# Altium schematic sheet Power Converter.SchDoc("Power Converter")
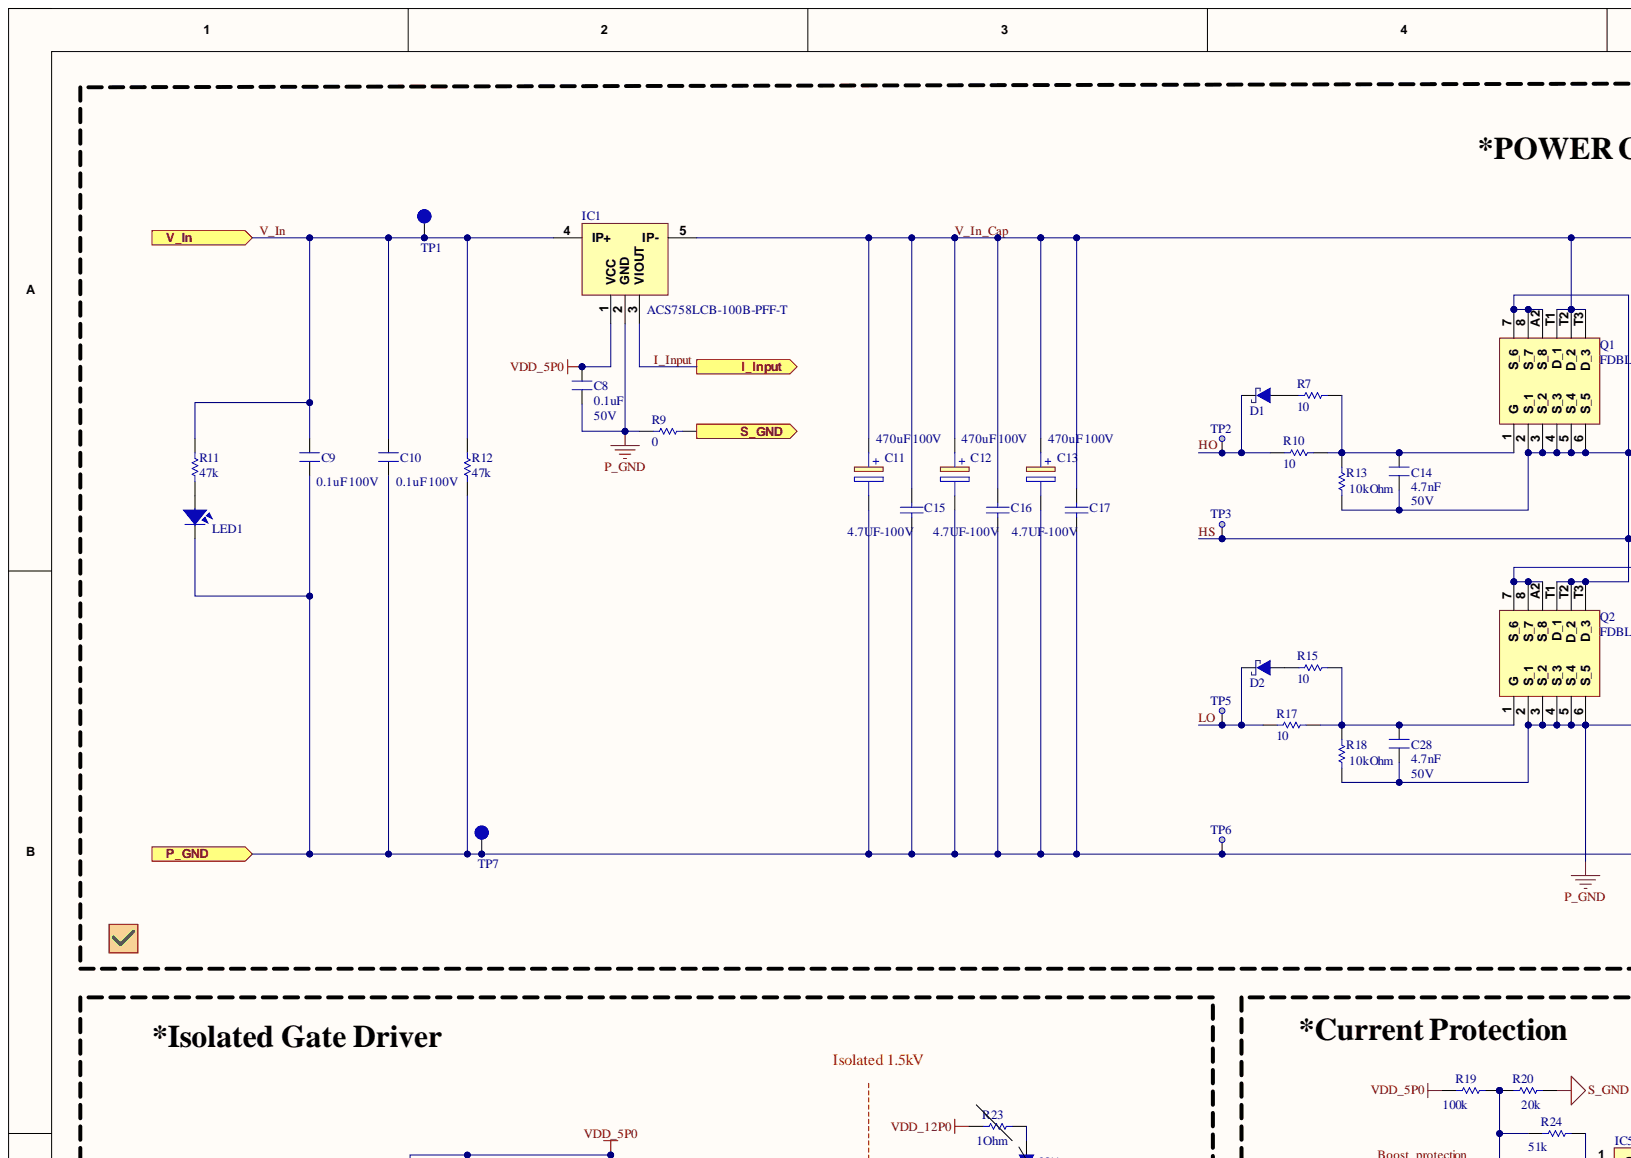
[diagram p0: Power Converter.SchDoc, top left]
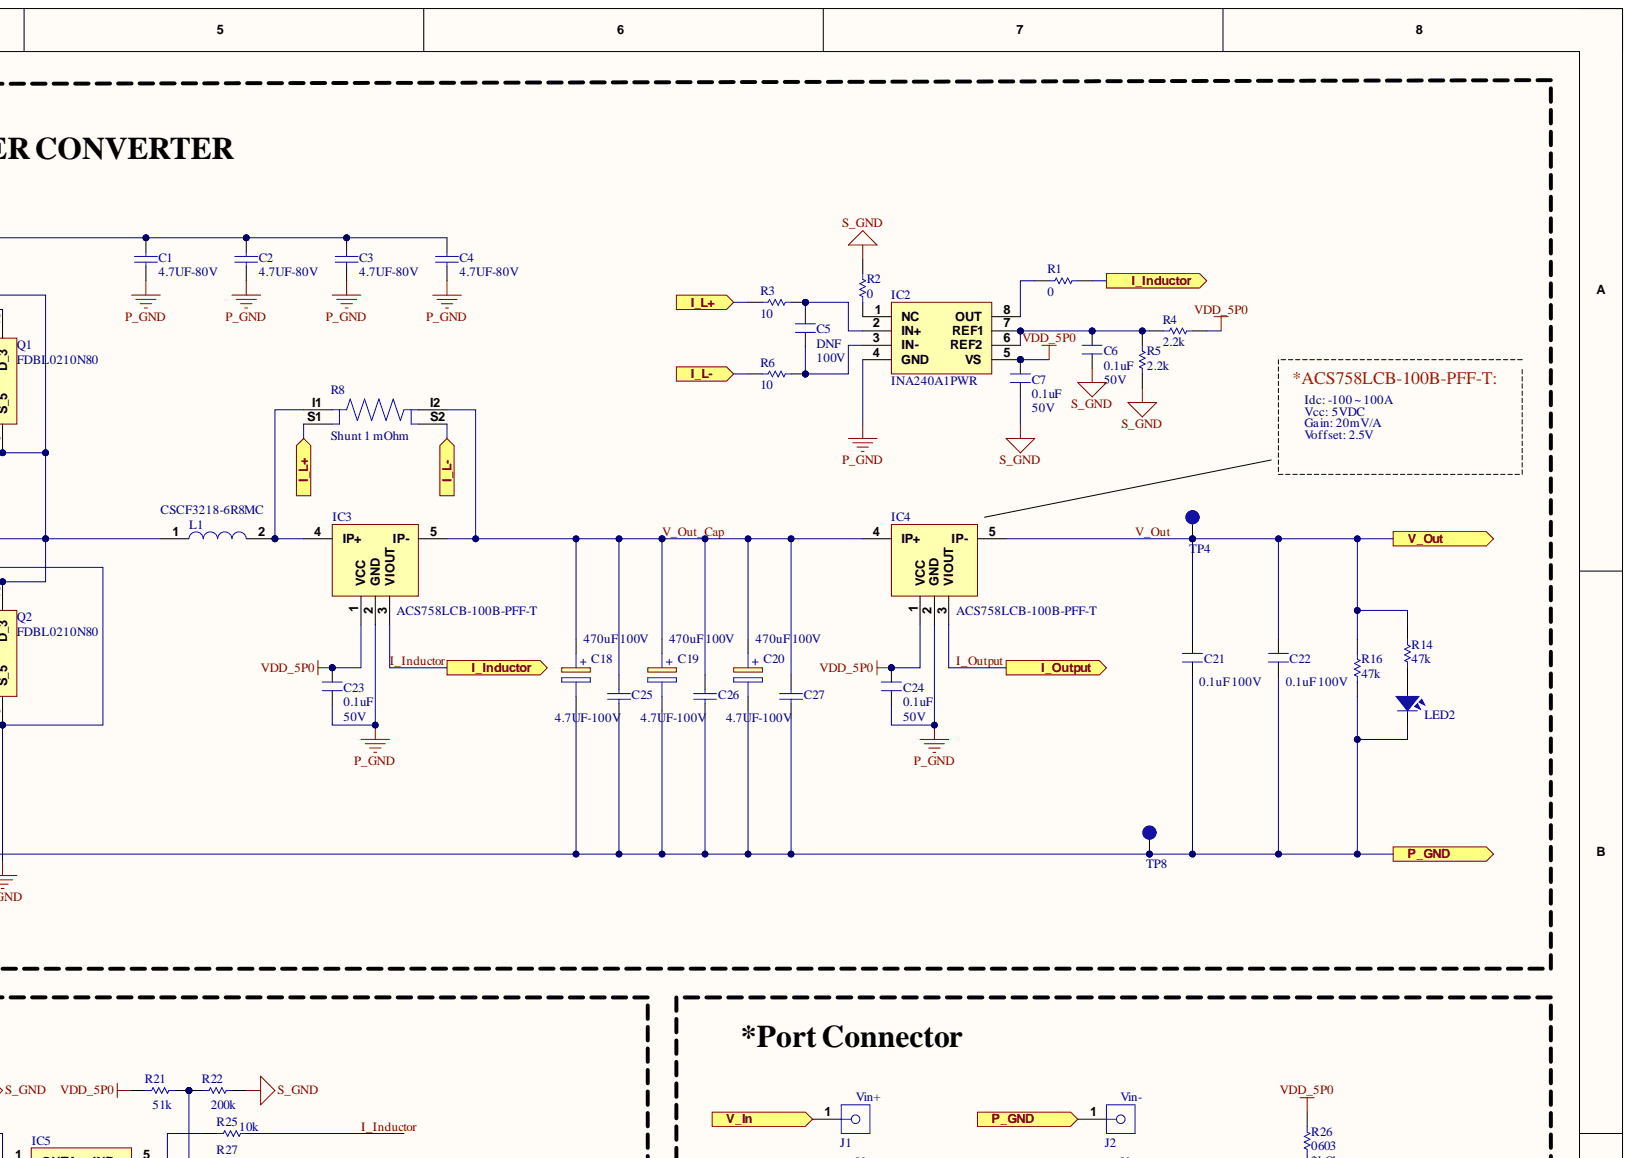
[diagram p1: Power Converter.SchDoc, top right]
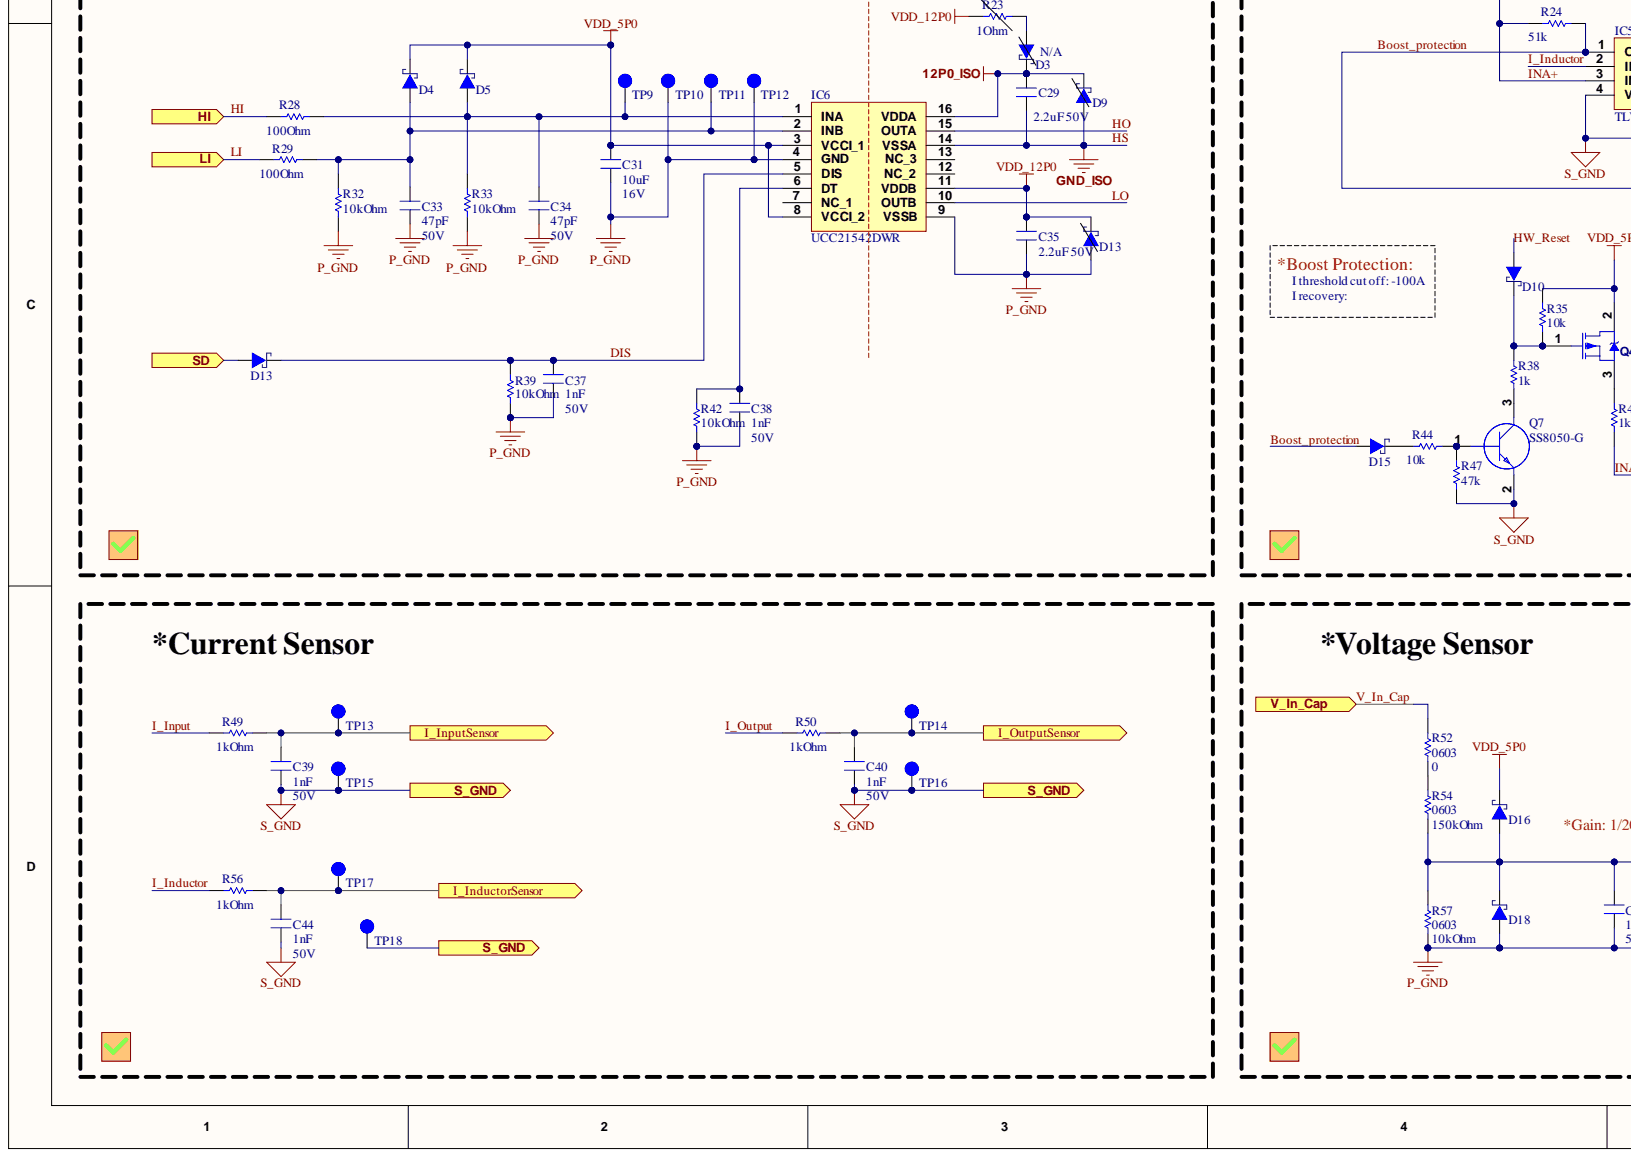
[diagram p2: Power Converter.SchDoc, bottom left]
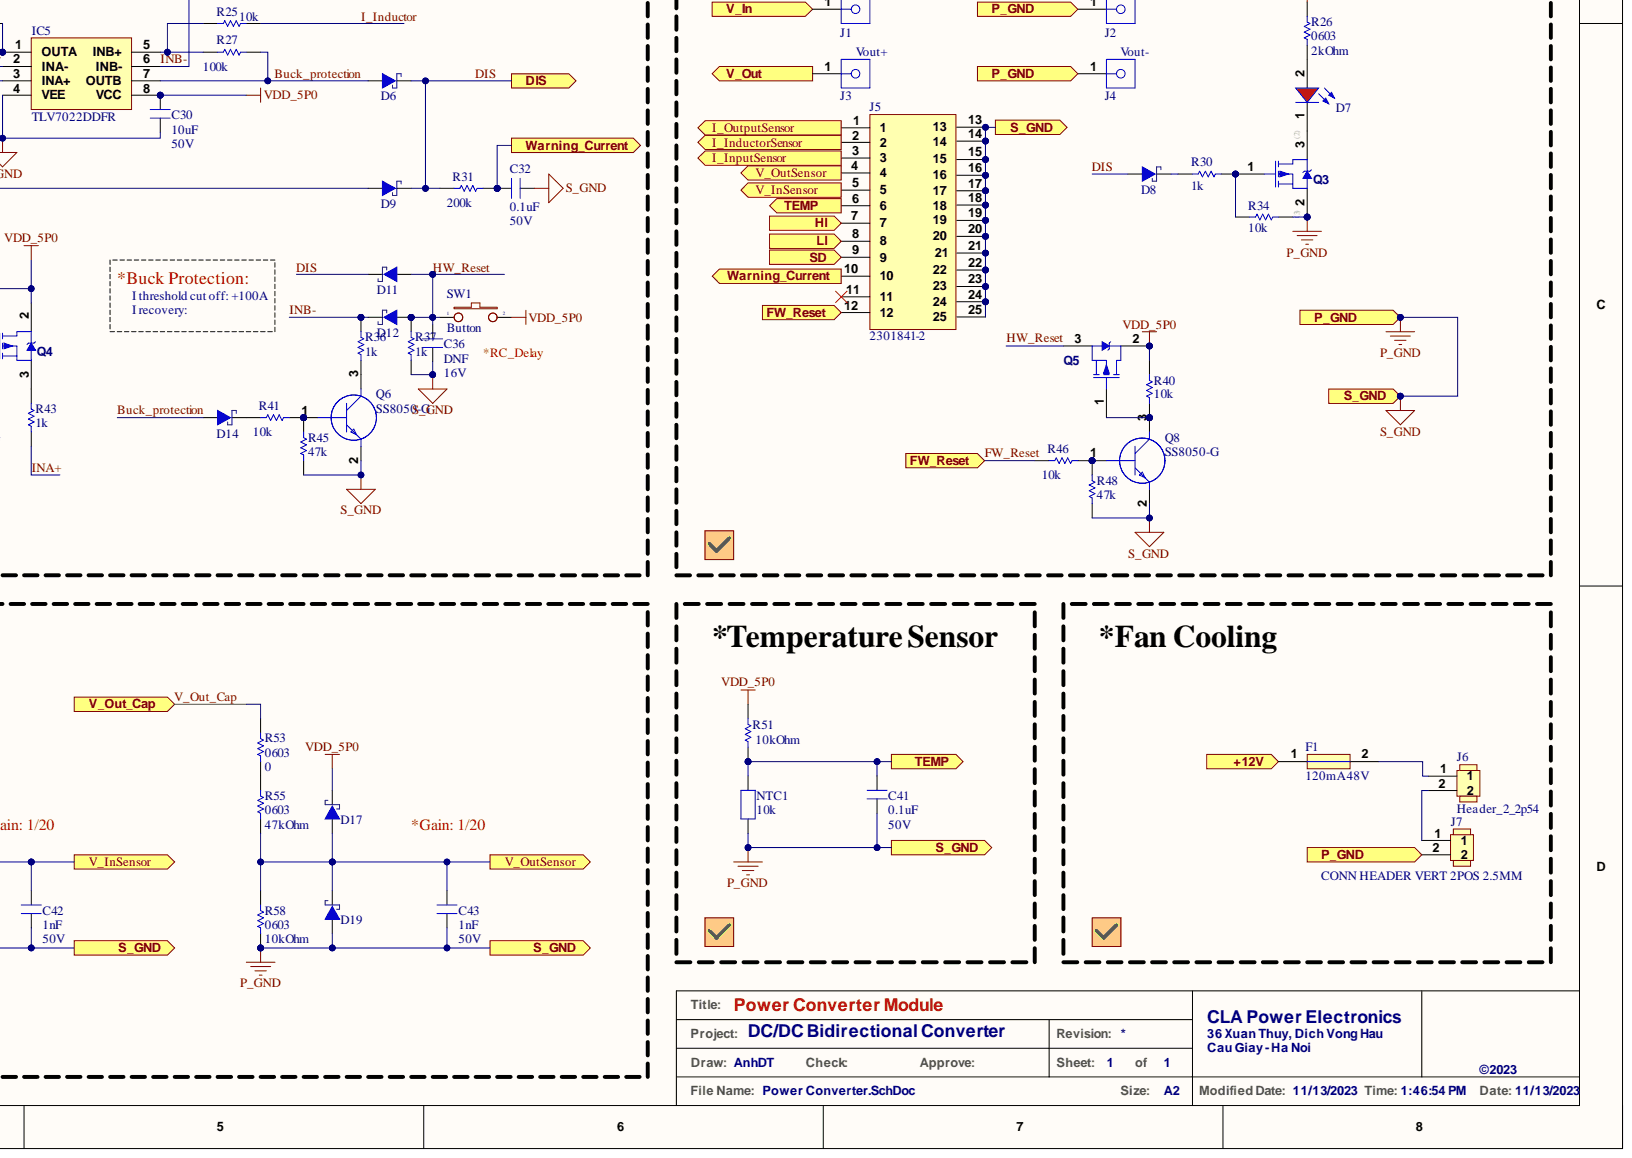
[diagram p3: Power Converter.SchDoc, bottom right]

## Parts
C1  value 4.7UF-80V  [C_1210]  (hidden comment: GRM32ER71K475KE14L)
C2  value 4.7UF-80V  [C_1210]  (hidden comment: GRM32ER71K475KE14L)
C3  value 4.7UF-80V  [C_1210]  (hidden comment: GRM32ER71K475KE14L)
C4  value 4.7UF-80V  [C_1210]  (hidden comment: GRM32ER71K475KE14L)
C5  DNF  value 100V  [C_0603]
C6  0.1uF  value 50V  [C_0603]
C7  0.1uF  value 50V  [C_0603]
C8  0.1uF  value 50V  [C_0603]
C9  0.1uF 100V  [C_1206]
C10  0.1uF 100V  [C_1206]
C11  470uF 100V  [CAP-ALU-13MM*30MM]
C12  470uF 100V  [CAP-ALU-13MM*30MM]
C13  470uF 100V  [CAP-ALU-13MM*30MM]
C14  4.7nF  value 50V  [C_0603]
C15  value 4.7UF-100V  [C_1210]  (hidden comment: GRM32ER71K475KE14L)
C16  value 4.7UF-100V  [C_1210]  (hidden comment: GRM32ER71K475KE14L)
C17  value 4.7UF-100V  [C_1210]  (hidden comment: GRM32ER71K475KE14L)
C18  470uF 100V  [CAP-ALU-13MM*30MM]
C19  470uF 100V  [CAP-ALU-13MM*30MM]
C20  470uF 100V  [CAP-ALU-13MM*30MM]
C21  0.1uF 100V  [C_1206]
C22  0.1uF 100V  [C_1206]
C23  0.1uF  value 50V  [C_0603]
C24  0.1uF  value 50V  [C_0603]
C25  value 4.7UF-100V  [C_1210]  (hidden comment: GRM32ER71K475KE14L)
C26  value 4.7UF-100V  [C_1210]  (hidden comment: GRM32ER71K475KE14L)
C27  value 4.7UF-100V  [C_1210]  (hidden comment: GRM32ER71K475KE14L)
C28  4.7nF  value 50V  [C_0603]
C29  2.2uF 50V  [C_1206]
C30  10uF  value 50V  [C_0603]
C31  10uF  value 16V  [C_0805]
C32  0.1uF  value 50V  [C_0603]
C33  47pF  value 50V  [C_0603]
C34  47pF  value 50V  [C_0603]
C35  2.2uF 50V  [C_1206]
C36  DNF  value 16V  [C_0805]
C37  1nF  value 50V  [C_0603]
C38  1nF  value 50V  [C_0603]
C39  1nF  value 50V  [C_0603]
C40  1nF  value 50V  [C_0603]
C41  0.1uF  value 50V  [C_0603]
C42  1nF  value 50V  [C_0603]
C43  1nF  value 50V  [C_0603]
C44  1nF  value 50V  [C_0603]
D1  B0520WS-7-F  [SOD323F]  (value not drawn)
D2  B0520WS-7-F  [SOD323F]  (value not drawn)
D3  PMEG2010AEJ,115  [SOD123]  (value not drawn)
D4  PMEG2010AEJ,115  [SOD323F]  (value not drawn)
D5  PMEG2010AEJ,115  [SOD323F]  (value not drawn)
D6  PMEG2010AEJ,115  [SOD323F]  (value not drawn)
D7  LED-SMD  [SM-LED-0805-Red]  (value not drawn)
D8  PMEG2010AEJ,115  [SOD323F]  (value not drawn)
D9  PMEG2010AEJ,115  [SOD123]  (value not drawn)
D9  PMEG2010AEJ,115  [SOD323F]  (value not drawn)
D10  PMEG2010AEJ,115  [SOD323F]  (value not drawn)
D11  PMEG2010AEJ,115  [SOD323F]  (value not drawn)
D12  PMEG2010AEJ,115  [SOD323F]  (value not drawn)
D13  PMEG2010AEJ,115  [SOD123]  (value not drawn)
D13  PMEG2010AEJ,115  [SOD323F]  (value not drawn)
D14  PMEG2010AEJ,115  [SOD323F]  (value not drawn)
D15  PMEG2010AEJ,115  [SOD323F]  (value not drawn)
D16  PMEG2010AEJ,115  [SOD323F]  (value not drawn)
D17  PMEG2010AEJ,115  [SOD323F]  (value not drawn)
D18  PMEG2010AEJ,115  [SOD323F]  (value not drawn)
D19  PMEG2010AEJ,115  [SOD323F]  (value not drawn)
F1  120mA 48V  [Fuse-SMD-1206]
IC1  ACS758LCB-100B-PFF-T  [ACS772ECB200BPFFT]
IC2  INA240A1PWR  [SOP65P640X120-8N]
IC3  ACS758LCB-100B-PFF-T  [ACS772ECB200BPFFT]
IC4  ACS758LCB-100B-PFF-T  [ACS772ECB200BPFFT]
IC5  TLV7022DDFR  [SOT65P280X110-8N]
IC6  UCC21542DWR  [SOIC127P1030X265-16N]
J1  Vin+  [HOLE_5mm_RED]
J2  Vin-  [HOLE_5mm_BLACK]
J3  Vout+  [HOLE_5mm_RED]
J4  Vout-  [HOLE_5mm_BLACK]
J5  2301841-2  [TE_2301841-2]
J6  Header_2_2p54  [JUMP_1x2]
J7  CONN HEADER VERT 2POS 2.5MM  [HEADER_2]
L1  CSCF3218-6R8MC  [CSCF3218-6R8MC]
LED1  LED SMD  [SM-LED-0805-Blue]  (value not drawn)
LED2  LED SMD  [SM-LED-0805-Blue]  (value not drawn)
NTC1  10k  [NTC 0805]
Q1  FDBL0210N80  [NTBLS1D5N10MC - duplicate]
Q2  FDBL0210N80  [NTBLS1D5N10MC - duplicate]
Q3  IRLML6402TRPBF  [SOT23-3]  (value not drawn)
Q4  IRLML6402TRPBF  [SOT23-3]  (value not drawn)
Q5  IRLML6402TRPBF  [SOT23-3]  (value not drawn)
Q6  SS8050-G  [sot23-3]
Q7  SS8050-G  [sot23-3]
Q8  SS8050-G  [sot23-3]
R1  0  [R_0603]
R2  0  [R_0603]
R3  10  [R_0603]
R4  2.2k  [R_0603]
R5  2.2k  [R_0603]
R6  10  [R_0603]
R7  10  [R_0805]
R8  Shunt 1 mOhm  value 1 mOhm  [PSG4/PSF4]
R9  value 0  [R_0603]  (hidden comment: 0603)
R10  10  [R_0805]
R11  47k  [R_0603]
R12  47k  [R_1206]
R13  value 10kOhm  [R_0603]  (hidden comment: 0603)
R14  47k  [R_0603]
R15  10  [R_0805]
R16  47k  [R_1206]
R17  10  [R_0805]
R18  value 10kOhm  [R_0603]  (hidden comment: 0603)
R19  value 100k  [R_0603]  (hidden comment: 0603)
R20  value 20k  [R_0603]  (hidden comment: 0603)
R21  51k  [R_0603]
R22  200k  [R_0603]
R23  value 1Ohm  [R_0603]  (hidden comment: 0603)
R24  value 51k  [R_0603]  (hidden comment: 0603)
R25  10k  [R_0603]
R26  0603  value 2kOhm  [R_0603]
R27  value 100k  [R_0603]  (hidden comment: 0603)
R28  value 100Ohm  [R_0603]  (hidden comment: 0603)
R29  value 100Ohm  [R_0603]  (hidden comment: 0603)
R30  1k  [R_0603]
R31  200k  [R_0603]
R32  value 10kOhm  [R_0603]  (hidden comment: 0603)
R33  value 10kOhm  [R_0603]  (hidden comment: 0603)
R34  10k  [R_0603]
R35  10k  [R_0603]
R36  1k  [R_0603]
R37  1k  [R_0603]
R38  1k  [R_0603]
R39  value 10kOhm  [R_0603]  (hidden comment: 0603)
R40  10k  [R_0603]
R41  10k  [R_0603]
R42  value 10kOhm  [R_0603]  (hidden comment: 0603)
R43  1k  [R_0603]
R44  10k  [R_0603]
R45  47k  [R_0603]
R46  10k  [R_0603]
R47  47k  [R_0603]
R48  47k  [R_0603]
R49  value 1kOhm  [R_0603]  (hidden comment: 0603)
R50  value 1kOhm  [R_0603]  (hidden comment: 0603)
R51  value 10kOhm  [R_0603]  (hidden comment: 0603)
R52  0603  value 0  [R_0603]
R53  0603  value 0  [R_0603]
R54  0603  value 150kOhm  [R_0603]
R55  0603  value 47kOhm  [R_0603]
R56  value 1kOhm  [R_0603]  (hidden comment: 0603)
R57  0603  value 10kOhm  [R_0603]
R58  0603  value 10kOhm  [R_0603]
SW1  Button  [BUTTON_2P_SMD]
TP1  Test Point  [TP_1mm_through_hole]  (value not drawn)
TP2  TP  [TP_1mm]  (no BOM)
TP3  TP  [TP_1mm]  (no BOM)
TP4  Test Point  [TP_1mm_through_hole]  (value not drawn)
TP5  TP  [TP_1mm]  (no BOM)
TP6  TP  [TP_1mm]  (no BOM)
TP7  Test Point  [TP_1mm_through_hole]  (value not drawn)
TP8  Test Point  [TP_1mm_through_hole]  (value not drawn)
TP9  Test Point  [TP_1mm_through_hole]  (value not drawn)
TP10  Test Point  [TP_1mm_through_hole]  (value not drawn)
TP11  Test Point  [TP_1mm_through_hole]  (value not drawn)
TP12  Test Point  [TP_1mm_through_hole]  (value not drawn)
TP13  Test Point  [TP_1mm_through_hole]  (value not drawn)
TP14  Test Point  [TP_1mm_through_hole]  (value not drawn)
TP15  Test Point  [TP_1mm_through_hole]  (value not drawn)
TP16  Test Point  [TP_1mm_through_hole]  (value not drawn)
TP17  Test Point  [TP_1mm_through_hole]  (value not drawn)
TP18  Test Point  [TP_1mm_through_hole]  (value not drawn)

## Ports
+12V, DIS, FW_Reset, HI, I_Inductor, I_InductorSensor, I_Input, I_InputSensor, I_L+, I_L-, I_Output, I_OutputSensor, LI, P_GND, S_GND, SD, TEMP, V_In, V_In_Cap, V_InSensor, V_Out, V_Out_Cap, V_OutSensor, Warning_Current

## Nets
12P0_ISO: C29.2 D3.2 D9.2 IC6.16(VDDA)
VDD_5P0: C7.1 C8.2 C23.2 C24.2 C30.2 C31.2 D4.2 D5.2 D16.2 D17.2 IC1.1(VCC) IC2.5(VS) IC3.1(VCC) IC4.1(VCC) IC5.8(VCC) IC6.3(VCCI_1) IC6.8(VCCI_2) Q4.2 Q5.2 R4.1 R19.1 R21.1 R26.2 R35.2 R40.2 R51.2 SW1.2
VDD_12P0: C35.1 D13.2 IC6.11(VDDB) R23.1
+12V: F1.1 ; port +12V
Boost_protection: D9.1 D15.1 IC5.1(OUTA) R24.2
Buck_protection: D6.1 D14.1 IC5.7(OUTB) R27.2
DIS: C37.2 D6.2 D8.1 D9.2 D11.2 D13.2 IC6.5(DIS) R31.1 R39.2 ; port DIS
FW_Reset: J5.12 R46.1 ; port FW_Reset
HI: J5.7 R28.1 ; port HI
HO: D1.2 IC6.15(OUTA) R10.1 TP2.1
HS: C14.1 C29.1 D9.1 IC6.14(VSSA) L1.1 Q1.2(S_1) Q1.3(S_2) Q1.4(S_3) Q1.5(S_4) Q1.6(S_5) Q1.7(S_6) Q1.8(S_7) Q1.A2(S_8) Q2.T1(D_1) Q2.T2(D_2) Q2.T3(D_3) R13.1 TP3.1
HW_Reset: C36.2 D10.1 D11.1 D12.1 Q5.3 R37.2 SW1.1
I_Inductor: IC3.3(VIOUT) IC5.2(INA-) R1.1 R25.2 R56.1 ; port I_Inductor
I_InductorSensor: C44.2 J5.2 R56.2 TP17.1 ; port I_InductorSensor
I_Input: IC1.3(VIOUT) R49.1 ; port I_Input
I_InputSensor: C39.2 J5.3 R49.2 TP13.1 ; port I_InputSensor
I_Output: IC4.3(VIOUT) R50.1 ; port I_Output
I_OutputSensor: C40.2 J5.1 R50.2 TP14.1 ; port I_OutputSensor
INA+: IC5.3(INA+) R19.2 R20.1 R24.1 R43.1
INB-: D12.2 IC5.6(INB-) R21.2 R22.1 R36.2
LI: J5.8 R29.1 ; port LI
LO: D2.2 IC6.10(OUTB) R17.1 TP5.1
P_GND: C1.1 C2.1 C3.1 C4.1 C6.1 C7.2 C8.1 C9.1 C10.1 C11.2 C12.2 C13.2 C15.1 C16.1 C17.1 C18.2 C19.2 C20.2 C21.1 C22.1 C23.1 C24.1 C25.1 C26.1 C27.1 C28.1 C30.1 C31.1 C32.2 C33.2 C34.2 C35.2 C36.1 C37.1 C38.1 C39.1 C40.1 C41.1 C42.1 C43.1 C44.1 D13.1 D18.1 D19.1 IC1.2(GND) IC2.4(GND) IC3.2(GND) IC4.2(GND) IC5.4(VEE) IC6.4(GND) IC6.9(VSSB) J2.1 J4.1 J5.13 J5.14 J5.15 J5.16 J5.17 J5.18 J5.19 J5.20 J5.21 J5.22 J5.23 J5.24 J5.25 J7.2 LED1.2 LED2.2 NTC1.1 Q2.2(S_1) Q2.3(S_2) Q2.4(S_3) Q2.5(S_4) Q2.6(S_5) Q2.7(S_6) Q2.8(S_7) Q2.A2(S_8) Q3.3 Q6.2 Q7.2 Q8.2 R2.2 R5.1 R9.1 R9.2 R12.1 R16.1 R18.1 R20.2 R22.2 R32.1 R33.1 R34.2 R37.1 R39.1 R42.1 R45.1 R47.1 R48.1 R57.1 R58.1 TP6.1 TP7.1 TP8.1 TP10.1 TP12.1 TP15.1 TP16.1 TP18.1 ; port P_GND, port S_GND
SD: D13.1 J5.9 ; port SD
TEMP: C41.2 J5.6 NTC1.2 R51.1 ; port TEMP
V_In: C9.2 C10.2 IC1.4(IP+) J1.1 R11.1 R12.2 TP1.1 ; port V_In
V_In_Cap: C1.2 C2.2 C3.2 C4.2 C11.1 C12.1 C13.1 C15.2 C16.2 C17.2 IC1.5(IP-) Q1.T1(D_1) Q1.T2(D_2) Q1.T3(D_3) R52.2 ; port V_In_Cap
V_InSensor: C42.2 D16.1 D18.2 J5.5 R54.1 R57.2 ; port V_InSensor
V_Out: C21.2 C22.2 IC4.5(IP-) J3.1 R14.1 R16.2 TP4.1 ; port V_Out
V_Out_Cap: C18.1 C19.1 C20.1 C25.2 C26.2 C27.2 IC3.5(IP-) IC4.4(IP+) R8.I2 R53.2 ; port V_Out_Cap
V_OutSensor: C43.2 D17.1 D19.2 J5.4 R55.1 R58.2 ; port V_OutSensor
Warning_Current: C32.1 J5.10 R31.2 ; port Warning_Current
NetC5_1: C5.1 IC2.2(IN+) R3.1
NetC5_2: C5.2 IC2.3(IN-) R6.1
NetC6_2: C6.2 IC2.6(REF2) IC2.7(REF1) R4.2 R5.2
NetC14_2: C14.2 Q1.1(G) R7.2 R10.2 R13.2
NetC28_2: C28.2 Q2.1(G) R15.2 R17.2 R18.2
NetC33_1: C33.1 D4.1 IC6.2(INB) R29.2 R32.2 TP11.1
NetC34_1: C34.1 D5.1 IC6.1(INA) R28.2 R33.2 TP9.1
NetC38_2: C38.2 IC6.6(DT) R42.2
NetD1_1: D1.1 R7.1
NetD2_1: D2.1 R15.1
NetD3_1: D3.1 R23.2
NetD7_1: D7.1 Q3.2
NetD7_2: D7.2 R26.1
NetD8_2: D8.2 R30.2
NetD10_2: D10.2 Q4.1 R35.1 R38.2
NetD14_2: D14.2 R41.1
NetD15_2: D15.2 R44.1
NetF1_2: F1.2 J6.1
NetIC2_1: IC2.1(NC) R2.1
NetIC2_8: IC2.8(OUT) R1.2
NetIC3_4: IC3.4(IP+) L1.2 R8.I1
NetIC5_5: IC5.5(INB+) R25.1 R27.1
NetJ6_2: J6.2 J7.1
NetLED1_1: LED1.1 R11.2
NetLED2_1: LED2.1 R14.2
NetQ3_1: Q3.1 R30.1 R34.1
NetQ4_3: Q4.3 R43.2
NetQ5_1: Q5.1 Q8.3 R40.1
NetQ6_1: Q6.1 R41.2 R45.2
NetQ6_3: Q6.3 R36.1
NetQ7_1: Q7.1 R44.2 R47.2
NetQ7_3: Q7.3 R38.1
NetQ8_1: Q8.1 R46.2 R48.2
NetR3_2: R3.2 R8.S1
NetR6_2: R6.2 R8.S2
NetR52_1: R52.1 R54.2
NetR53_1: R53.1 R55.2

Not connected: IC6 7(NC_1) 12(NC_2) 13(NC_3); J5 11

## Library data of the parts
C1-C4 C15-C17 C25-C27 = Cap 1210: CAP CER 4.7uF 80V X7R 1210; Manufacturer: TDK Corporation; Description std: CAP CER 4.7UF 100V X7S 1210; Category: Capacitors; Component Kind: Standard; Digikey Price/1KU: 0.56250; Digikey Price/1unit: 1.64; Library Name: DC_DC_Power_V3.0.IntLib; Link: https://www.digikey.com/product-detail/en/tdk-corporation/C3225X7S2A475M200AB/445-6043-2-ND/2443521; Package: 1210; Part Number: GRM32ER71K475KE14L
C5 = Cap_Cer_0603_1nF: Manufacturer: Murata Electronics; Part Code: S1200-000081; Part Number: GCM188R71E102KA37D; Description SEL: CAP CER 1000PF 25V X7R 0603; Point: 2; Component Kind: Standard; Description: DNF; Footprint Type: SMD; Library Name: S1200_Capacitor.SchLib
C6 C7 = Cap_Cer_0603_1nF: CAP CER 0.1UF 50V X7R 0603; Manufacturer: Murata Electronics; Part Code: S1200-000081; Part Number: GCM188R71E102KA37D; Description SEL: CAP CER 1000PF 25V X7R 0603; Point: 2; Component Kind: Standard; Footprint Type: SMD; Library Name: S1200_Capacitor.SchLib
C8 C23 C24 C32 = Cap_Cer_0603_1nF_25V: CAP CER 0.1UF 16V X5R 0603; Manufacturer: Murata Electronics; Part Code: S1200-000082; Part Number: CL21A106KOCLRNC; Description SEL: CAP CER 10UF 16V X5R 0805; Point: 2; Component Kind: Standard; Footprint Type: SMD; Library Name: S1200_Capacitor.SchLib
C9 C10 C21 C22 C29 C35 = Cap_Cer_1206_1uF: Ceramic Capacitor 1206 (+-10% TH)/(+10%SMD); Manufacturer: TDK Corporation; Part Code: S1200-000129; Part Number: C3216X7R2A105K160AA; Description SEL: CAP CER 1UF 100V X7R 1206; Point: 2; Component Kind: Standard; Footprint Type: SMD; Library Name: S1200_Capacitor.SchLib
C11-C13 C18-C20 = 100ZLH470MEFC16X31.5: CAP ALUM 470UF 20% 80V RADIAL; Manufacturer_Name: Panasonic Electronic Components; Part Number: EEU-FS1K471L; Value: 470uF 80V; Arrow Part Number: EEU-FS1K471L; Arrow Price/Stock: https://www.arrow.com/en/products/100zlh470mefc16x31.5/rubycon?region=nac; Component Kind: Standard; Height: 33.5mm; Mouser Part Number: EEU-FS1K471L; Mouser Price/Stock: https://www.digikey.com/en/products/detail/panasonic-electronic-components/EEU-FS1K471L/9597020; Library Name: Tienanh.SchLib
C14 C28 = Cap_Cer_0603_1nF_25V: CAP CER 0805 4.7NF 50V C0G 5%; Manufacturer: KEMET; Part Code: S1200-000082; Part Number: C0805C472J5GECAUTO; Point: 2; Component Kind: Standard; Description SEL: CAP CER 0805 4.7NF 50V C0G 5%; Footprint Type: SMD; Library Name: S1200_Capacitor.SchLib
C30 = Cap_Cer_0603_1nF_25V: CAP CER 10UF 16V X5R 0805; Manufacturer: Murata Electronics; Part Code: S1200-000082; Part Number: CL21A106KOCLRNC; Point: 2; Component Kind: Standard; Description SEL: CAP CER 10UF 16V X5R 0805; Footprint Type: SMD; Library Name: S1200_Capacitor.SchLib
C31 = Cap_Cer_0805_10pF: CAP CER 10uF 16V X7R 0805; Manufacturer: Kemet; Part Code: S1200-000116; Part Number: CL21A106KOCLRNC; Point: 2; Component Kind: Standard; Description SEL: CAP CER 10uF 16V X7R 0805; Footprint Type: SMD; Library Name: S1200_Capacitor.SchLib
C33 C34 C37-C40 C42-C44 = Cap_Cer_0603_1nF_25V: CAP CER 1nF 50V X7R 0603; Manufacturer: Murata Electronics; Part Code: S1200-000082; Part Number: GCM188R71E102KA37D; Description SEL: CAP CER 1000PF 25V X7R 0603; Point: 2; Component Kind: Standard; Footprint Type: SMD; Library Name: S1200_Capacitor.SchLib
C36 = Cap_Cer_0805_10pF: Manufacturer: Kemet; Part Code: S1200-000116; Part Number: CL21A106KOCLRNC; Description SEL: CAP CER 10uF 16V X7R 0805; Point: 2; Component Kind: Standard; Description: DNF; Footprint Type: SMD; Library Name: S1200_Capacitor.SchLib
C41 = Cap_Cer_0805_10pF: CAP CER 0.1uF 50V X7R 0603; Manufacturer: Kemet; Part Code: S1200-000116; Part Number: CL10C680JB81PNC; Description SEL: CAP CER 68pF 50V C0G 0603; Point: 2; Component Kind: Standard; Footprint Type: SMD; Library Name: S1200_Capacitor.SchLib
D1-D3 D6 D8 D9 D9-D13 D13-D19 = PMEG2010AEJ,115: DIODE SCHOTTKY 20V 1A SOD323F; Manufacturer: Nexperia USA Inc.; Current: 1A (DC); Category: DIODE; Component Kind: Standard; Footprint type: SMD; Footprint/Package: SOD-323F; Part Number: PMEG2010AEJ,115; Voltage: 20 V; Library Name: Motor Control SELEX MOTORS JSC.SchLib
D4 D5 = PMEG2010AEJ,115: DIODE SCHOTTKY 30V 0.2A SOD323F; Manufacturer: Nexperia USA Inc.; Part Number: BAT54HT1G; Current: 0.2A (DC); Voltage: 20 V; Category: DIODE; Component Kind: Standard; Footprint type: SMD; Footprint/Package: SOD-323F; Library Name: Motor Control SELEX MOTORS JSC.SchLib
D7 = LED-SMD: LED RED DIFFUSED 0805 SMD; Manufacturer: OSRAM Opto Semiconductors Inc.; Part Number: LS R976-NR-1; Category: LED; Component Kind: Standard; Footprint type: SMD; Library Name: Motor Control SELEX MOTORS JSC.SchLib
F1 = Fuse: PTC 120mA 48V 2410; Manufacturer_Name: Bel Circuit Protection; Manufacturer_Part_Number: 0679L1000-01; Arrow Part Number: 0679L1000-01; Arrow Price/Stock: https://www.arrow.com/en/products/0679l1000-01/bel-group; Component Kind: Standard; Datasheet Link: https://belfuse.com/resources/datasheets/circuitprotection/ds-cp-0679l-series.pdf; Height: 2.79mm; Library Name: Tienanh.SchLib
IC1 IC3 IC4 = ACS758LCB-100B-PFF-T: Integrated Circuit; Manufacturer_Name: Allegro Microsystems; Arrow Part Number: ACS758LCB-100B-PFF-T; Arrow Price/Stock: https://www.arrow.com/en/products/acs758lcb-100b-pff-t/allegro-microsystems?region=nac; Component Kind: Standard; Datasheet Link: https://www.allegromicro.com/-/media/files/datasheets/acs758-datasheet.ashx; Height: 7.1mm; Manufacturer_Part_Number: ACS758LCB-100B-PFF-T; Mouser Part Number: 250-758LCB100BPFFT; Mouser Price/Stock: https://www.mouser.co.uk/ProductDetail/Allegro-MicroSystems/ACS758LCB-100B-PFF-T?qs=pUKx8fyJudA0WtlqV%2Fcrcw%3D%3D; Library Name: Tienanh.SchLib
IC2 = INA240A1PWR: Integrated Circuit; Manufacturer_Name: Texas Instruments; Arrow Part Number: INA240A1PWR; Arrow Price/Stock: https://www.arrow.com/en/products/ina240a1pwr/texas-instruments?region=nac; Component Kind: Standard; Datasheet Link: http://www.ti.com/lit/gpn/INA240; Height: 1.2mm; Manufacturer_Part_Number: INA240A1PWR; Mouser Part Number: 595-INA240A1PWR; Mouser Price/Stock: https://www.mouser.co.uk/ProductDetail/Texas-Instruments/INA240A1PWR?qs=aVyJF2WnouTDXnRi6tpqfA%3D%3D
IC5 = TLV7022DDFR: IC COMPARATOR 2 GEN PUR TSOT23-8; Manufacturer_Name: Texas Instruments; Arrow Part Number: TLV7022DDFR; Arrow Price/Stock: https://www.arrow.com/en/products/tlv7022ddfr/texas-instruments?region=nac; Component Kind: Standard; Height: 1.1mm; Mouser Part Number: 595-TLV7022DDFR; Mouser Price/Stock: https://www.mouser.co.uk/ProductDetail/Texas-Instruments/TLV7022DDFR?qs=DPoM0jnrROVunnoIjN7Ztg%3D%3D; Part Number: TLV7022DDFR
IC6 = UCC21542DWR: REINFORCED ISOLATION DUAL-CHANNE; Manufacturer_Name: Texas Instruments; Component Kind: Standard; Datasheet Link: https://www.ti.com/lit/ds/symlink/ucc21542.pdf?ts=1623395410745&ref_url=https%253A%252F%252Fwww.ti.com%252Fstore%252Fti%252Fen%252Fp%252Fproduct%252F%253Fp%253DUCC21542DWKR; Height: 2.65mm; Mouser Part Number: 595-UCC21542DWR; Mouser Price/Stock: https://www.mouser.co.uk/ProductDetail/Texas-Instruments/UCC21542DWR?qs=DRkmTr78QARxjB5fL7Hhaw%3D%3D; Part Number: UCC21542DWR
J1-J4 = CONN_1P: Electric Pole 1; Component Kind: Standard; Footprint type: TH; Footprint/Package: Nonstandard; Library Name: Motor Control SELEX MOTORS JSC.SchLib
J5 = 2301841-2: 25 Position D-Sub Receptacle, Female Sockets Connector; MF: TE Connectivity; Availability: Unavailable; Component Kind: Standard; Library Name: 2301841-2.IntLib; MP: 2301841-2; Package: None; Price: None; SnapEDA_Link: https://www.snapeda.com/parts/2301841-2/TE%20Connectivity%20AMP%20Connectors/view-part/3597269/?ref=snap; TE_purchase_URL: https://www.te.com/usa-en/product-2301841-2.html?te_bu=Cor&te_type=disp&te_campaign=seda_glo_cor-seda-global-disp-prtnr-fy19-seda-model-bom-cta_sma-317_1&elqCampaignId=32493
J6 = Header_2_2p54: Part Code: S1900-000020; Point: 2; Component Kind: Standard; Description SEL: Header_2_2p54; Footprint Type: TH; Library Name: S1900_Connector.SchLib
J7 = Header_2: Manufacturer: JST Sales America Inc.; Part Code: S1900-000037; Part Number: B2B-XH-A(LF)(SN); Point: 2; Component Kind: Standard; Description: CONN HEADER VERT 2POS 2.5MM; Description SEL: CONN HEADER VERT 2POS 2.5MM; Footprint Type: TH; Library Name: S1900_Connector.SchLib; Value: Header_2
L1 = IHDF1300AEEH4R7K10: 6.8 µH Shielded Wirewound Inductor 50 A 1.6mOhm Max Nonstandard Mn-Zn Ferrite Low Loss; Manufacturer_Name: Vishay; Component Kind: Standard; Datasheet Link: https://www.vishay.com/docs/34538/ihdf-1300ae-10.pdf; Height: 15.4mm; Mouser Part Number: CSCF3218-6R8MC; Mouser Price/Stock: https://www.mouser.co.uk/ProductDetail/Vishay-Dale/IHDF1300AEEH4R7K10?qs=rkhjVJ6%2F3EKAMBQJjlTUTQ%3D%3D; Part Number: CSCF3218-6R8MC
LED1 LED2 = LED-SMD-0805: LED BLUE 1/4W 0805; Component Kind: Standard; Library Name: syhaunguyen.SchLib
NTC1 = NTC 10k 1%: THERMISTOR NTC 10KOHM 3940K 0805; Manufacturer: Vishay Beyschlag/Draloric/BC Components; Part Code: S1100-000169; Part Number: NTCS0805E3103JHT; Point: 2; Power: 1/10W; Tolerance: 0.1%; Component Kind: Standard; Description SEL: THERMISTOR NTC 10KOHM 3940K 0805; Footprint Type: SMD; Library Name: Tienanh.SchLib
Q1 Q2 = IPLU300N04S4R8XTMA1: MOSFET N-CH 80V 240A 8HPSOF; Manufacturer_Name: Infineon; Arrow Part Number: IPLU300N04S4R8XTMA1; Component Kind: Standard; Height: 2.3mm; Mouser Part Number: FDBL0210N80; Part Number: FDBL0210N80
Q3 = S1555-000011: MOSFET N-CH 20V 3.7A; Manufacturer: INFINEON; Part Number: DMP2160U-7; Database Table Name: S1555_Transistor_Fet$; Description SEL: P-Channel 20 V 3.7A (Ta) 1.3W (Ta) Surface Mount Micro3™/SOT-23; Point: 3; Component Kind: Standard; Footprint Type: SMD; Packaging: SOT23-3; Part Code: S1555-000011; Value: 20V 3.7A; Library Name: Selex_Component_Lib.SVNDbLib
Q4 Q5 = S1555-000011: MOSFET P-CH 20V 3.7A; Manufacturer: INFINEON; Database Table Name: S1555_Transistor_Fet$; Description SEL: P-Channel 20 V 3.7A (Ta) 1.3W (Ta) Surface Mount Micro3™/SOT-23; Point: 3; Component Kind: Standard; Footprint Type: SMD; Packaging: SOT23-3; Part Code: S1555-000011; Part Number: IRLML6402; Value: 20V 3.7A; Library Name: Selex_Component_Lib.SVNDbLib
Q6-Q8 = SS8050-G: Transistor BJT NPN; Manufacturer_Name: Comchip Technology; Arrow Part Number: SS8050-G; Arrow Price/Stock: https://www.arrow.com/en/products/ss8050-g/comchip-technology?region=nac; Component Kind: Standard; Datasheet Link: https://datasheet.datasheetarchive.com/originals/distributors/DKDS-12/229454.pdf; Height: 1.15mm; Manufacturer_Part_Number: SS8050-G; Mouser Part Number: 750-SS8050-G; Mouser Price/Stock: https://www.mouser.co.uk/ProductDetail/Comchip-Technology/SS8050-G?qs=LLUE9lz1YbcHg%252BWLMAtcrQ%3D%3D
R1 R2 = Resistor_0603_10Ohm 1%: RES 0 OHM 1% 1/8W 0603; Manufacturer: KOA Speer Electronics, Inc.; Part Code: S1100-000044; Part Number: RK73H1JRTTD10R0F; Description SEL: RES 10 OHM 1% 1/8W 0603; Point: 2; Value: 8.2-10kOhm; Component Kind: Standard; Footprint Type: SMD; Library Name: S1100_Resistor_FerriteBead.SchLib; Power: 1/8W; Tolerance: 1%
R3 R6 = Resistor_0603_10Ohm 1%: RES 10 OHM 1% 1/8W 0603; Manufacturer: KOA Speer Electronics, Inc.; Part Code: S1100-000044; Part Number: RK73H1JRTTD10R0F; Point: 2; Component Kind: Standard; Description SEL: RES 10 OHM 1% 1/8W 0603; Footprint Type: SMD; Library Name: S1100_Resistor_FerriteBead.SchLib; Power: 1/8W; Tolerance: 1%
R4 R5 = Resistor_0603_10Ohm 1%: RES 2.2k OHM 1% 1/8W 0603; Manufacturer: KOA Speer Electronics, Inc.; Part Code: S1100-000044; Part Number: RK73H1JRTTD10R0F; Description SEL: RES 10 OHM 1% 1/8W 0603; Point: 2; Component Kind: Standard; Footprint Type: SMD; Library Name: S1100_Resistor_FerriteBead.SchLib; Power: 1/8W; Tolerance: 1%
R7 R10 R15 R17 = Resistor_0805_10Ohm: RES 10 OHM 1% 1/8W 0805; Manufacturer: YAGEO; Part Code: S1100-000072; Part Number: RE0805FRE0710RL; Description SEL: RES SMD 10 OHM 1% 1/8W 0805; Point: 2; Component Kind: Standard; Footprint Type: SMD; Library Name: S1100_Resistor_FerriteBead.SchLib; Power: 1/8W; Tolerance: 1%
R8 = PSG4NTEBL500F: RES 1m OHM 1% 10W NONSTANDARD; Manufacturer: KOA Speer Electronics, Inc.; Category: Resistor; Component Kind: Standard; Footprint type: SMD; Footprint/Package: Nonstandard; Part Number: PSG4NTEBL500F; Tolerance: ±1%; Library Name: Motor Control SELEX MOTORS JSC.SchLib
R9 = Resistor_0603_10kOhm 1%: RES 0 OHM 1% 1/10W 0603; Manufacturer: YAGEO; Part Code: S1100-000043; Part Number: RC0603FR-7W10KL; Description SEL: RES 10K OHM 1% 1/10W 0603; Point: 2; Component Kind: Standard; Footprint Type: SMD; Library Name: S1100_Resistor_FerriteBead.SchLib; Power: 1/10W; Tolerance: 1%
R11 R14 = Resistor_0603_10Ohm 1%: RES 47K OHM 1% 1/10W 0603; Manufacturer: YAGEO; Part Code: S1100-000044; Part Number: RC0603FR-07100KL; Description SEL: RES 10 OHM 1% 1/8W 0603; Point: 2; Power: 1/8W; Component Kind: Standard; Footprint Type: SMD; Library Name: S1100_Resistor_FerriteBead.SchLib; Tolerance: 1%
R12 R16 = Resistor_1206_0Ohm: RES 47K OHM 1/4W 1206; Manufacturer: YAGEO; Part Code: S1100-000177; Part Number: RC1206JR-070RL; Description SEL: RES 0 OHM JUMPER 1/4W 1206; Point: 2; Tolerance: Jumper; Component Kind: Standard; Footprint Type: SMD; Library Name: S1100_Resistor_FerriteBead.SchLib; Power: 1/4W
R13 R18 R51 = Resistor_0603_10kOhm 1%: RES 10K OHM 1% 1/10W 0603; Manufacturer: YAGEO; Part Code: S1100-000043; Part Number: RC0603FR-7W10KL; Point: 2; Component Kind: Standard; Description SEL: RES 10K OHM 1% 1/10W 0603; Footprint Type: SMD; Library Name: S1100_Resistor_FerriteBead.SchLib; Power: 1/10W; Tolerance: 1%
R19 R27 = Resistor_0603_1_MegaOhm_1%: RES 100k OHM 1% 1/10W 0603; Manufacturer: YAGEO; Part Code: S1100-000171; Part Number: RC0603FR-071ML; Description SEL: RES 1M OHM 1% 1/10W 0603; Point: 2; Component Kind: Standard; Footprint Type: SMD; Library Name: S1100_Resistor_FerriteBead.SchLib; Power: 1/10W; Tolerance: 1%
R20 = Resistor_0603_1_MegaOhm_1%: RES 20k OHM 1% 1/10W 0603; Manufacturer: YAGEO; Part Code: S1100-000171; Part Number: RC0603FR-071ML; Description SEL: RES 1M OHM 1% 1/10W 0603; Point: 2; Component Kind: Standard; Footprint Type: SMD; Library Name: S1100_Resistor_FerriteBead.SchLib; Power: 1/10W; Tolerance: 1%
R21 = Resistor_0603_10Ohm 1%: RES 51k OHM 1% 1/8W 0603; Manufacturer: KOA Speer Electronics, Inc.; Part Code: S1100-000044; Part Number: RT0603FRE07300KL; Description SEL: RES 300k OHM 1% 1/8W 0603; Point: 2; Component Kind: Standard; Footprint Type: SMD; Library Name: S1100_Resistor_FerriteBead.SchLib; Power: 1/8W; Tolerance: 1%
R22 = Resistor_0603_10Ohm 1%: RES 200k OHM 1% 1/8W 0603; Manufacturer: KOA Speer Electronics, Inc.; Part Code: S1100-000044; Part Number: RT0603FRE07300KL; Description SEL: RES 300k OHM 1% 1/8W 0603; Point: 2; Component Kind: Standard; Footprint Type: SMD; Library Name: S1100_Resistor_FerriteBead.SchLib; Power: 1/8W; Tolerance: 1%
R23 = Resistor_0603_1Ohm: RES 1OHM 1% 1/8W 0603; Manufacturer: Vishay Dale; Part Code: S1100-000140; Part Number: MCT06030C1008FP500; Point: 2; Component Kind: Standard; Description SEL: RES 1 OHM 1% 1/8W 0603; Footprint Type: SMD; Library Name: S1100_Resistor_FerriteBead.SchLib; Power: 1/8W; Tolerance: 1%
R24 = Resistor_0603_1_MegaOhm_1%: RES 51k OHM 1% 1/10W 0603; Manufacturer: YAGEO; Part Code: S1100-000171; Part Number: RC0603FR-071ML; Description SEL: RES 1M OHM 1% 1/10W 0603; Point: 2; Component Kind: Standard; Footprint Type: SMD; Library Name: S1100_Resistor_FerriteBead.SchLib; Power: 1/10W; Tolerance: 1%
R25 = Resistor_0603_10Ohm 1%: RES 10k OHM 1% 1/8W 0603; Manufacturer: KOA Speer Electronics, Inc.; Part Code: S1100-000044; Part Number: RNCF0805BTE250K; Description SEL: RES 250k OHM 1% 1/8W 0603; Point: 2; Component Kind: Standard; Footprint Type: SMD; Library Name: S1100_Resistor_FerriteBead.SchLib; Power: 1/8W; Tolerance: 1%
R26 = Resistor_0603_4.7kOhm: RES 2K OHM 1% 1/10W 0603; Manufacturer: YAGEO; Part Code: S1100-000158; Part Number: RC0603FR-074K7L; Description SEL: RES 4.7K OHM 1% 1/10W 0603; Point: 2; Component Kind: Standard; Footprint Type: SMD; Library Name: S1100_Resistor_FerriteBead.SchLib; Power: 1/10W; Tolerance: 1%
R28 R29 = Resistor_0603_100Ohm: RES 100 OHM 1% 1/8W 0603; Manufacturer: KOA Speer Electronics, Inc.; Part Code: S1100-000052; Part Number: RK73H1JRTTD1000F; Point: 2; Component Kind: Standard; Description SEL: RES 100 OHM 1% 1/8W 0603; Footprint Type: SMD; Library Name: S1100_Resistor_FerriteBead.SchLib; Power: 1/8W; Tolerance: 1%
R30 R31 R38 R43 = Resistor_0603_10Ohm 1%: RES 200K OHM 1% 1/10W 0603; Manufacturer: YAGEO; Part Code: S1100-000044; Part Number: RC0603FR-13200KL; Point: 2; Power: 1/8W; Component Kind: Standard; Description SEL: RES 200K OHM 1% 1/10W 0603; Footprint Type: SMD; Library Name: S1100_Resistor_FerriteBead.SchLib; Tolerance: 1%
R32 R33 R39 R42 = Resistor_0603_100Ohm: RES 10 kOHM 1% 1/8W 0603; Manufacturer: KOA Speer Electronics, Inc.; Part Code: S1100-000052; Part Number: RK73H1JRTTD1000F; Description SEL: RES 100 OHM 1% 1/8W 0603; Point: 2; Component Kind: Standard; Footprint Type: SMD; Library Name: S1100_Resistor_FerriteBead.SchLib; Power: 1/8W; Tolerance: 1%
R34 R41 R44 R46 = Resistor_0603_10Ohm 1%: RES 10K OHM 1% 1/10W 0603; Manufacturer: YAGEO; Part Code: S1100-000044; Part Number: RC0603FR-13200KL; Description SEL: RES 200K OHM 1% 1/10W 0603; Point: 2; Power: 1/8W; Component Kind: Standard; Footprint Type: SMD; Library Name: S1100_Resistor_FerriteBead.SchLib; Tolerance: 1%
R35 R40 R45 R47 R48 = Resistor_0603_10Ohm 1%: RES 47K OHM 1% 1/10W 0603; Manufacturer: YAGEO; Part Code: S1100-000044; Part Number: RC0603FR-13200KL; Description SEL: RES 200K OHM 1% 1/10W 0603; Point: 2; Power: 1/8W; Component Kind: Standard; Footprint Type: SMD; Library Name: S1100_Resistor_FerriteBead.SchLib; Tolerance: 1%
R36 R37 = Resistor_0603_10Ohm 1%: RES 1K OHM 1% 1/10W 0603; Manufacturer: YAGEO; Part Code: S1100-000044; Part Number: RC0603FR-13200KL; Description SEL: RES 200K OHM 1% 1/10W 0603; Point: 2; Power: 1/8W; Component Kind: Standard; Footprint Type: SMD; Library Name: S1100_Resistor_FerriteBead.SchLib; Tolerance: 1%
R49 R50 R56 = Resistor_0603_1kOhm: RES 1K OHM 1% 1/10W 0603; Manufacturer: Vishay Dale; Part Code: S1100-000139; Part Number: CRCW06031K00FKTA; Point: 2; Component Kind: Standard; Description SEL: RES 1K OHM 1% 1/10W 0603; Footprint Type: SMD; Library Name: S1100_Resistor_FerriteBead.SchLib; Power: 1/10W; Tolerance: 1%
R52 R53 = Resistor_0603_220kOhm: RES SMD 0 OHM 1% 1/8W 0603; Manufacturer: Vishay Beyschlag/Draloric/BC Components; Part Code: S1100-000053; Part Number: MCT06030C2203FP500; Description SEL: RES SMD 220K OHM 1% 1/8W 0603; Point: 2; Component Kind: Standard; Footprint Type: SMD; Library Name: S1100_Resistor_FerriteBead.SchLib; Power: 1/8W; Tolerance: 1%
R54 R55 = Resistor_0603_220kOhm: RES SMD 150K OHM 1% 1/8W 0603; Manufacturer: Vishay Beyschlag/Draloric/BC Components; Part Code: S1100-000053; Part Number: MCT06030C2203FP500; Description SEL: RES SMD 220K OHM 1% 1/8W 0603; Point: 2; Component Kind: Standard; Footprint Type: SMD; Library Name: S1100_Resistor_FerriteBead.SchLib; Power: 1/8W; Tolerance: 1%
R57 R58 = Resistor_0603_220kOhm: RES SMD 220K OHM 1% 1/8W 0603; Manufacturer: Vishay Beyschlag/Draloric/BC Components; Part Code: S1100-000053; Part Number: MCT06030C2203FP500; Point: 2; Component Kind: Standard; Description SEL: RES SMD 220K OHM 1% 1/8W 0603; Footprint Type: SMD; Library Name: S1100_Resistor_FerriteBead.SchLib; Power: 1/8W; Tolerance: 1%
SW1 = SW2: Nút nhấn 2 chân dán 3x6x2.5mm; Category: Connector; Component Kind: Standard; Library Name: BMS v2.1.SCHLIB
TP1 TP4 TP7-TP18 = Test Point: PC TEST POINT COMPACT RED; Manufacturer: Keystone Electronics; Part Number: 5005; Component Kind: Standard; Library Name: Tienanh.SchLib
TP2 TP3 TP5 TP6 = Test_Point_1mm: Test Point; ComponentLink1Description: New link; ComponentLink2Description: New link; Library Name: S1400_Test_Point.SchLib; Library Ref: Selex_Library; Creator: SELEX MOTORS JSC
